# Altium schematic sheet TEMP_CTRL.SchDoc
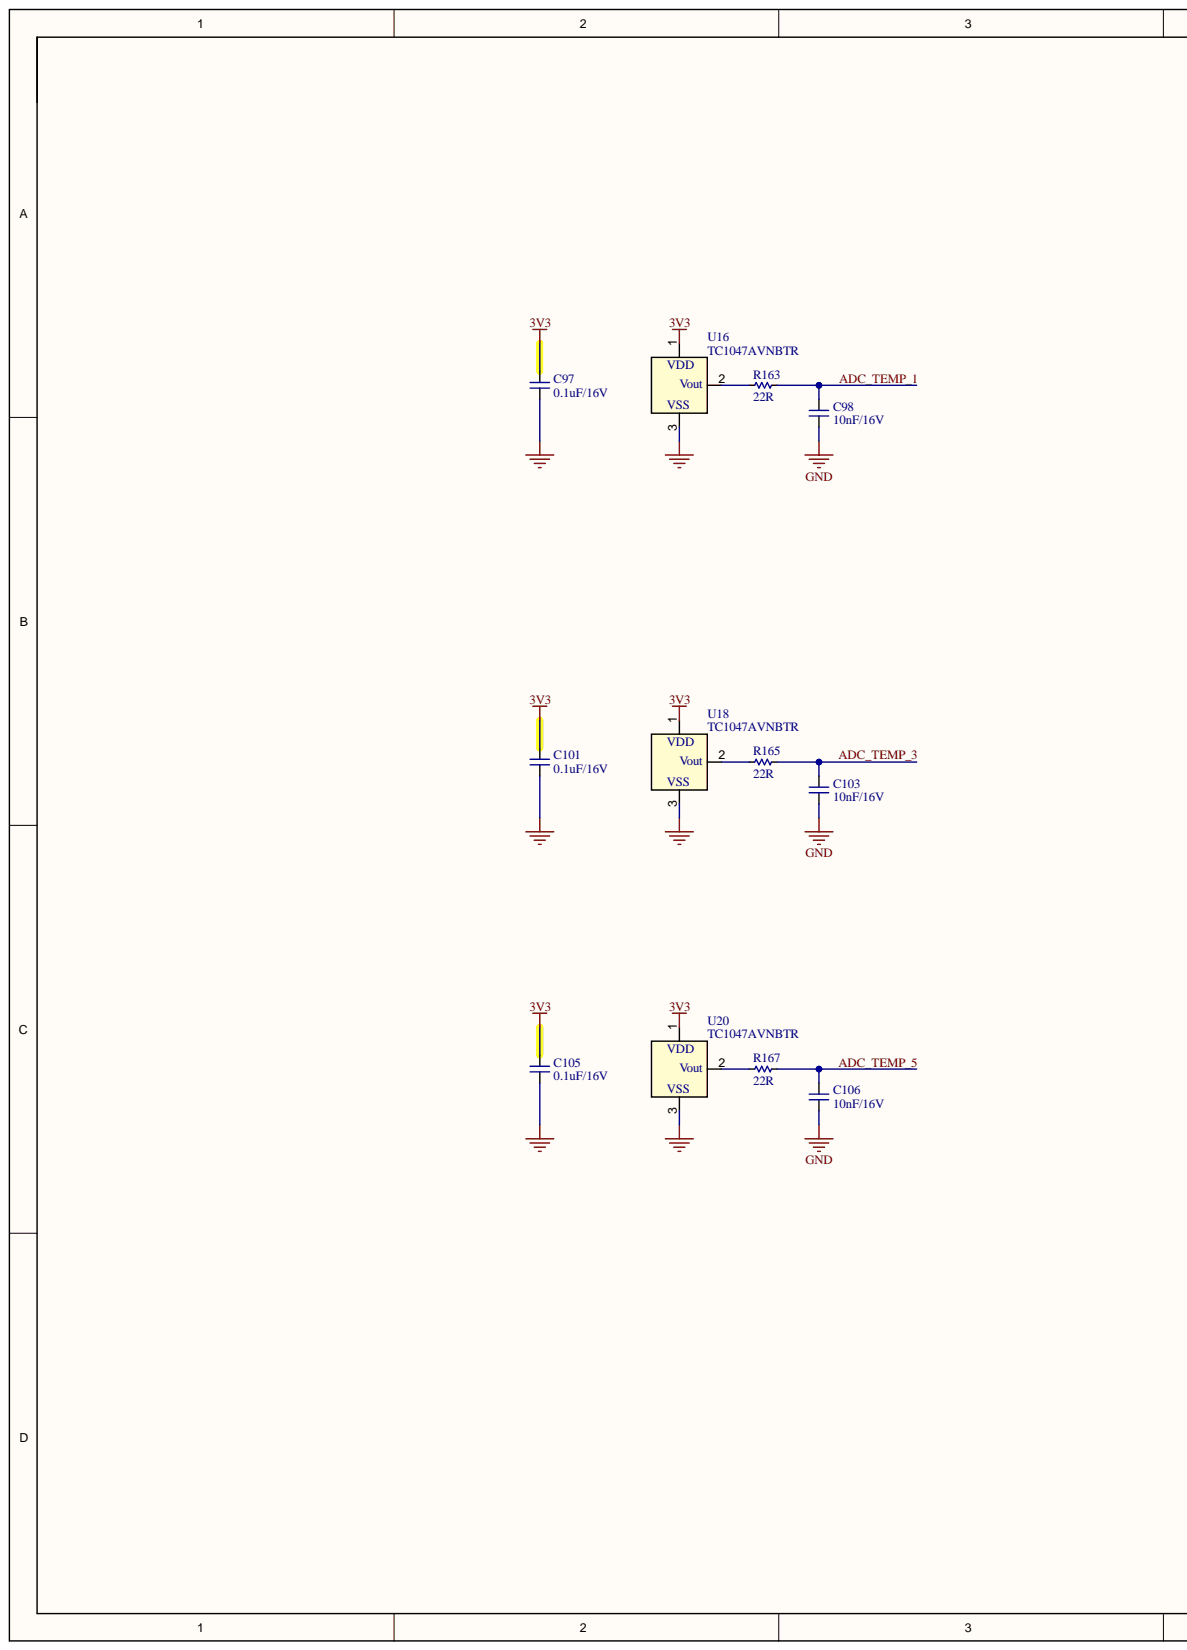
[diagram p0: TEMP_CTRL.SchDoc, left]
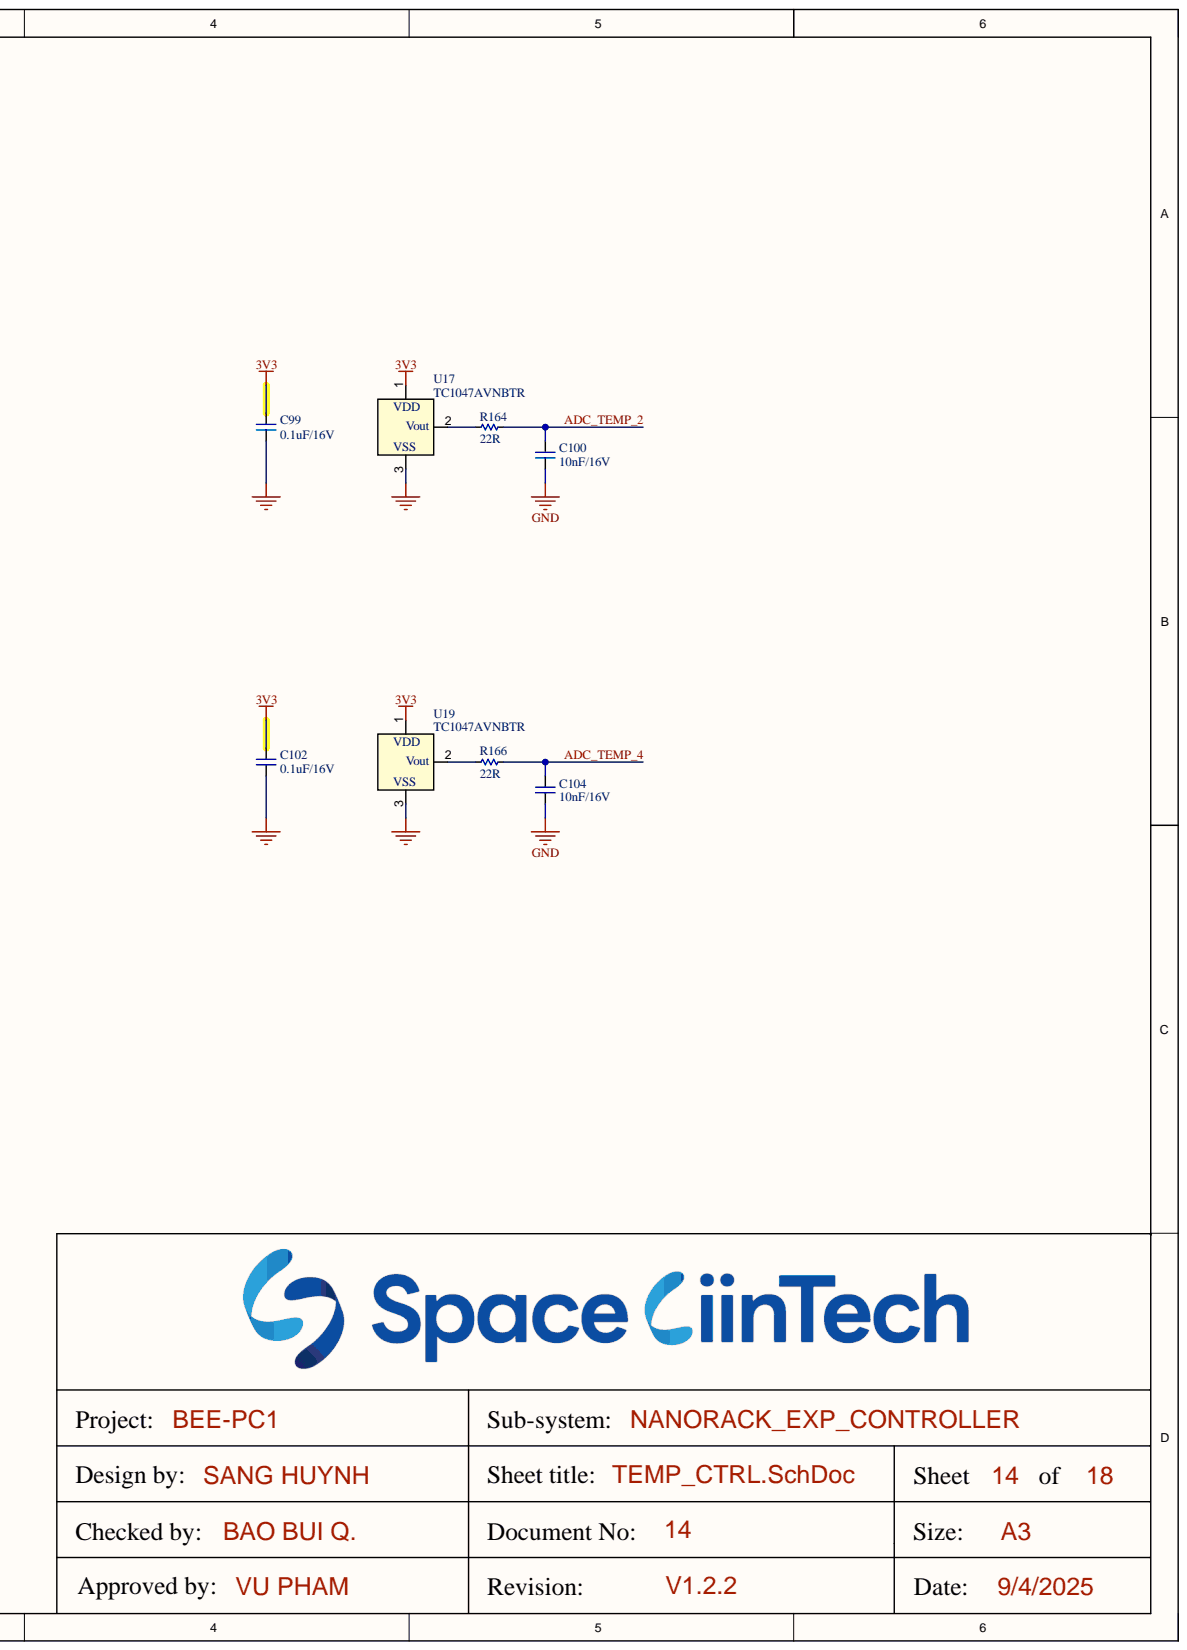
[diagram p1: TEMP_CTRL.SchDoc, right]

## Parts
C97  0.1uF/16V  [CAP_C_1608X80]
C98  10nF/16V  [CAP_C_1608X80]
C99  0.1uF/16V  [CAP_C_1608X80]
C100  10nF/16V  [CAP_C_1608X80]
C101  0.1uF/16V  [CAP_C_1608X80]
C102  0.1uF/16V  [CAP_C_1608X80]
C103  10nF/16V  [CAP_C_1608X80]
C104  10nF/16V  [CAP_C_1608X80]
C105  0.1uF/16V  [CAP_C_1608X80]
C106  10nF/16V  [CAP_C_1608X80]
R163  22R  [RES_C_1608X60]
R164  22R  [RES_C_1608X60]
R165  22R  [RES_C_1608X60]
R166  22R  [RES_C_1608X60]
R167  22R  [RES_C_1608X60]
U16  TC1047AVNBTR  [SOT-23_MC_MCH]
U17  TC1047AVNBTR  [SOT-23_MC_MCH]
U18  TC1047AVNBTR  [SOT-23_MC_MCH]
U19  TC1047AVNBTR  [SOT-23_MC_MCH]
U20  TC1047AVNBTR  [SOT-23_MC_MCH]

## Nets
3V3: C97.2 C99.2 C101.2 C102.2 C105.2 U16.1 U17.1 U18.1 U19.1 U20.1
GND: C97.1 C98.1 C99.1 C100.1 C101.1 C102.1 C103.1 C104.1 C105.1 C106.1 U16.3 U17.3 U18.3 U19.3 U20.3
ADC_TEMP_1: C98.2 R163.2
ADC_TEMP_2: C100.2 R164.2
ADC_TEMP_3: C103.2 R165.2
ADC_TEMP_4: C104.2 R166.2
ADC_TEMP_5: C106.2 R167.2
NetR163_1: R163.1 U16.2
NetR164_1: R164.1 U17.2
NetR165_1: R165.1 U18.2
NetR166_1: R166.1 U19.2
NetR167_1: R167.1 U20.2

## Library data of the parts
C97-C106 = CAP_SMD: CAP_Chip; Value: 33pF; Component Kind: Standard; Library Name: LABLIB.SchLib
R163-R167 = _RES_B_2: RES; Component Kind: Standard; Library Name: LABLIB.SchLib
U16-U20 = TC1047AVNBTR: No Description Available; Manufacturer_Name: Microchip; Component Kind: Standard; Manufacturer_Part_Number: TC1047AVNBTR; RefDes: RefDes; Type: Type; Copyright: Copyright (C) 2025 Ultra Librarian. All rights reserved.; Library Name: COMM_SCHLIB.SchLib
